# Altium schematic sheet motor.SchDoc
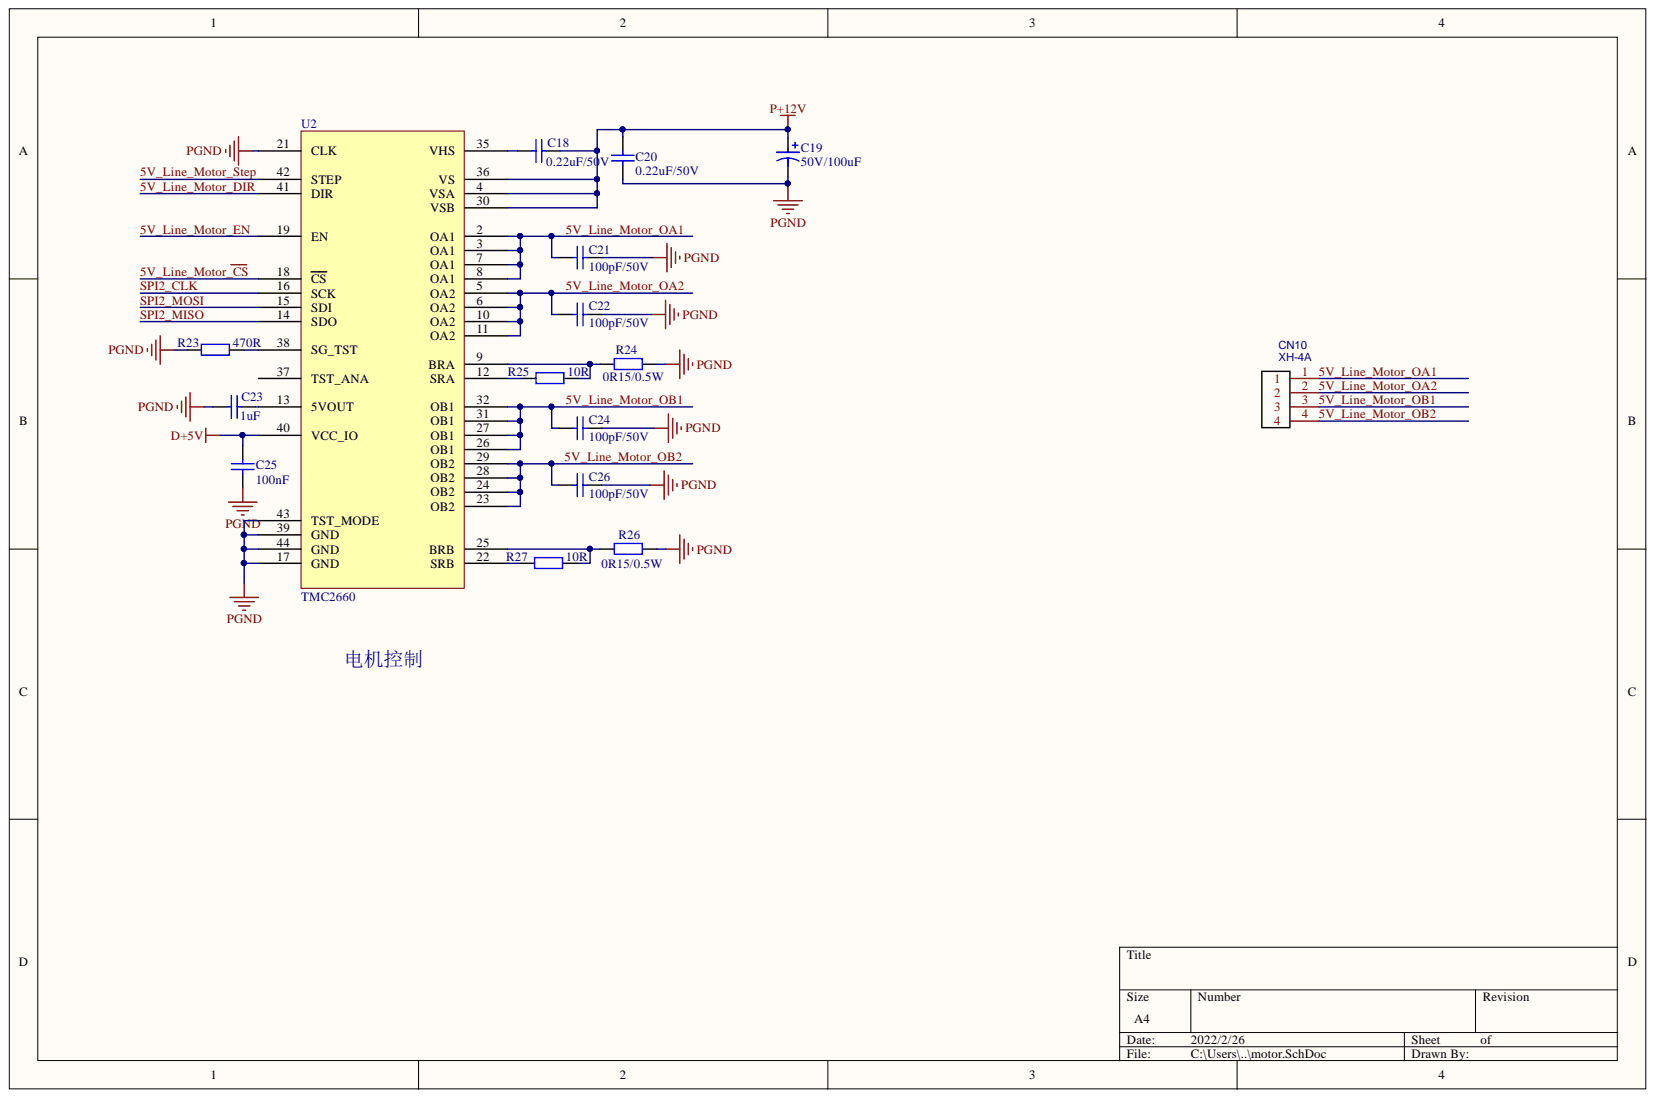
[diagram p0: motor.SchDoc]

## Parts
C18  0.22uF/50V  [C0603]
C19  50V/100uF  [SMD,10x10.5mm]
C20  0.22uF/50V  [C0603]
C21  100pF/50V  [C0603]
C22  100pF/50V  [C0603]
C23  1uF  [C0603]
C24  100pF/50V  [C0603]
C25  100nF  [C0603]
C26  100pF/50V  [C0603]
CN10  XH-4A  [XH-4P]
R23  470R  [R0603]
R24  0R15/0.5W  [R2010]
R25  10R  [R0603]
R26  0R15/0.5W  [R2010]
R27  10R  [R0603]
U2  TMC2660  [QFP-44]

## Nets
D+5V: C25.1 U2.40(VCC_IO)
P+12V: C18.1 C19.1 C20.1 U2.4(VSA) U2.30(VSB) U2.36(VS)
PGND: C19.2 C20.2 C21.1 C22.1 C23.1 C24.1 C25.2 C26.1 R23.1 R24.2 R26.2 U2.17(GND) U2.21(CLK) U2.39(GND) U2.43(TST_MODE) U2.44(GND)
5V_Line_Motor_~{CS}: U2.18(CS)
5V_Line_Motor_DIR: U2.41(DIR)
5V_Line_Motor_EN: U2.19(EN)
5V_Line_Motor_OA1: C21.2 CN10.1 U2.2(OA1) U2.3(OA1) U2.7(OA1) U2.8(OA1)
5V_Line_Motor_OA2: C22.2 CN10.2 U2.5(OA2) U2.6(OA2) U2.10(OA2) U2.11(OA2)
5V_Line_Motor_OB1: C24.2 CN10.3 U2.26(OB1) U2.27(OB1) U2.31(OB1) U2.32(OB1)
5V_Line_Motor_OB2: C26.2 CN10.4 U2.23(OB2) U2.24(OB2) U2.28(OB2) U2.29(OB2)
5V_Line_Motor_Step: U2.42(STEP)
SPI2_CLK: U2.16(SCK)
SPI2_MISO: U2.14(SDO)
SPI2_MOSI: U2.15(SDI)
NetC18_2: C18.2 U2.35(VHS)
NetC23_2: C23.2 U2.13(5VOUT)
NetR23_2: R23.2 U2.38(SG_TST)
NetR24_1: R24.1 R25.2 U2.9(BRA)
NetR25_1: R25.1 U2.12(SRA)
NetR26_1: R26.1 R27.2 U2.25(BRB)
NetR27_1: R27.1 U2.22(SRB)

Not connected: U2 37(TST_ANA)

## Library data of the parts
C18 C20-C26 = 0402 10nF (103) 10% 50V: 贴片电容; Component Kind: Standard; ComponentLink1Description: 供应商链接; ComponentLink1URL: http://www.szlcsc.com/product/details_15869.html; Library Name: Cap.SchLib; Package: 0402; Supplier: LC; SuppliersPartNumber: C15195
C19 = 100uF 16V: 电解电容; Component Kind: Standard; ComponentLink1Description: 供应商链接; ComponentLink1URL: https://item.szlcsc.com/139751.html; Library Name: Cap.SchLib; Package: SMD,6.3x5.4mm; Supplier: LC; SuppliersPartNumber: C128466
CN10 = XH-4A: BOM_Manufacturer: BOOMELE; BOM_JLCPCB Part Class: Extended Part; BOM_Manufacturer Part: XH-4A; BOM_Supplier: LCSC; BOM_Supplier Part: C37815; Component Kind: Standard; spicePre: C; spiceSymbolName: XH-4A
R23-R27 = 0603 4.7Ω (4R70) 1%: 贴片电阻; Component Kind: Standard; ComponentLink1Description: 供应商链接; ComponentLink1URL: http://www.szlcsc.com/product/details_23891.html; Library Name: RES.SchLib; Package: 0603; Supplier: LC; SuppliersPartNumber: C23164
U2 = TMC260: Component Kind: Standard; Library Name: C-LifeReal_1.SchLib
